# Altium schematic sheet 02 EXTRUDER.SchDoc("02 EXTRUDER")
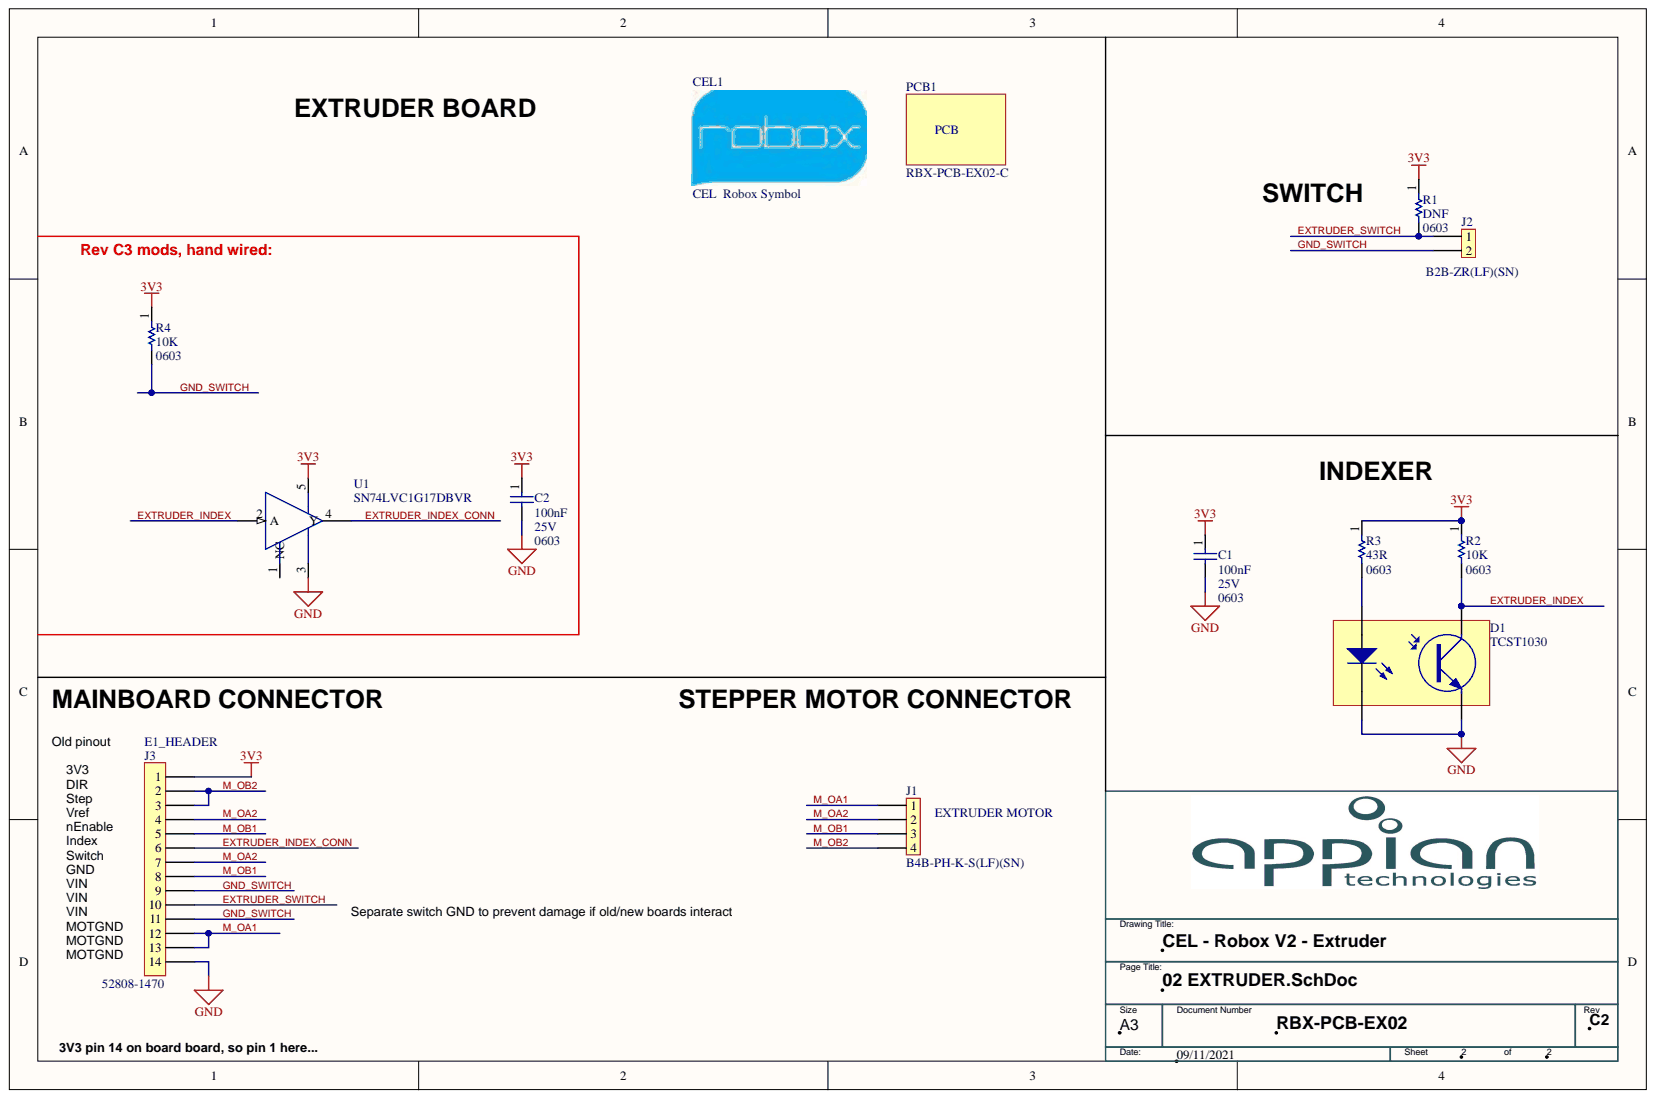
[diagram p0: 02 EXTRUDER.SchDoc]

## Parts
C1  value 100nF  VOLTAGE: 25V  PACKAGE: 0603  [0603C]  (hidden comment: 06033C104KAT2A)
C2  value 100nF  VOLTAGE: 25V  PACKAGE: 0603  [0603C]  (hidden comment: 06033C104KAT2A)
CEL1  CEL  Robox Symbol  MANUFACTURER: CEL  [CEL_ROBOX_SYM]  (no BOM)
D1  TCST1030  [TCST1030]
J1  B4B-PH-K-S(LF)(SN)  [CONN_JST_B4B-PH-K-S(LF)(SN)]
J2  B2B-ZR(LF)(SN)  [CONN_JST_ B2B-ZR(LF)(SN)]
J3  52808-1470  [CONN_MOLEX_52808-1470]
PCB1  RBX-PCB-EX02-C  [RBX-PCB-EX02-C]
R1  DNF 0603  value DNF  PACKAGE: 0603  [0603R]
R2  value 10K  PACKAGE: 0603  [0603R]  (hidden comment: ERJ3EKF1002V)
R3  value 43R  PACKAGE: 0603  [0603R]  (hidden comment: ERJ3EKF43R0V)
R4  value 10K  PACKAGE: 0603  [0603R]  (hidden comment: ERJ3EKF1002V)
U1  SN74LVC1G17DBVR  [SC70-5_2.0X1.3_0.65]

## Nets
3V3: C1.1 C2.1 J3.1 R1.1 R2.1 R3.1 R4.1 U1.5
GND: C1.2 C2.2 D1.E D1.K J3.14 U1.3
EXTRUDER_INDEX: D1.C R2.2 U1.2(A)
EXTRUDER_INDEX_CONN: J3.6 U1.4(Y)
EXTRUDER_SWITCH: J2.1 J3.10 R1.2
GND_SWITCH: J2.2 J3.9 J3.11 R4.2
M_OA1: J1.1 J3.12 J3.13
M_OA2: J1.2 J3.4 J3.7
M_OB1: J1.3 J3.5 J3.8
M_OB2: J1.4 J3.2 J3.3
NetD1_A: D1.A R3.2

Not connected: U1 1(NC)

## Library data of the parts
C1 C2 = 06033C104KAT2A: Capacitor, Ceramic, 100nF, 25V, 0603, X7R, 10%; MANUFACTURER: AVX; PARTNUMBER: 06033C104KAT2A; Library Name: Capacitors.SCHLIB; Component Kind: Standard
CEL1 = CEL Logo: PARTNUMBER: CEL  Robox Symbol; Library Name: Symbols.SCHLIB; Description: CEL Logo
D1 = TCST1030: LED & Detector, Infrered, 950nm, GaAs; MANUFACTURER: VISHAY; VALUE: TCST1030; PARTNUMBER: TCST1030; Component Kind: Standard; Library Name: Extruder.SchLib
J1 = B4B-PH-K-S(LF)(SN): Header, 4 Pin, 2mm, TH, Vertical; MANUFACTURER: JST; STATUS: Drawn 16/8/18 PT for CEL Impact; PARTNUMBER: B4B-PH-K-S(LF)(SN); Library Name: Connectors.SCHLIB; Component Kind: Standard
J2 = B2B-ZR(LF)(SN): Header, 2 Pin, 1.5mm, TH, Vertical; MANUFACTURER: JST; STATUS: Drawn 08/01/19 PT for CEL Robox Extruder; PARTNUMBER: B2B-ZR(LF)(SN); Library Name: Connectors.SCHLIB; Component Kind: Standard
J3 = 52808-1470: FCC/FPC, 14 way, 1mm pitch, vertical, Non-ZIF, Easy-On, Tin; MANUFACTURER: Molex; STATUS: Drawn 13/09/18 PT for CEL Robox 2; PARTNUMBER: 52808-1470; Library Name: Connectors.SCHLIB; Component Kind: Standard
PCB1 = RBX-PCB-EX02: PCB; MANUFACTURER: Appian Technologies; PARTNUMBER: RBX-PCB-EX02-C; Component Kind: Standard; Library Name: PCB assemblies.SCHLIB
R1 = DNF R 0603: Resistor, DNF 0603; manufacturer: NA; PARTNUMBER: DNF 0603; Library Name: Resistors.SCHLIB; Component Kind: Standard
R2 R4 = ERJ3EKF1002V: Resistor, 0603, 10K, 1%, 100mW, 75V; MANUFACTURER: Panasonic; PARTNUMBER: ERJ3EKF1002V; Library Name: Resistors.SCHLIB; Component Kind: Standard
R3 = ERJ3EKF43R0V: Resistor, 0603, 43R, 1%, 100mW, 75V; MANUFACTURER: Panasonic; STATUS: Drawn 30/05/19 PT; PARTNUMBER: ERJ3EKF43R0V; Library Name: Resistors.SCHLIB; Component Kind: Standard
U1 = SN74LVC1G17DBVR: IC SCHMITT-TRIGGER BUFFER SINGLE 1 INPUT SC70-5, 1V65-5V5, -40C +125C; MANUFACTURER: Texas Instruments; STATUS: Modified from G06 inverter 09/11/21 PT for CEL Extruder C; PARTNUMBER: SN74LVC1G17DBVR; Library Name: Semiconductors.SchLib; Component Kind: Standard
